# Altium schematic sheet Sheet2.SchDoc(Sheet2)
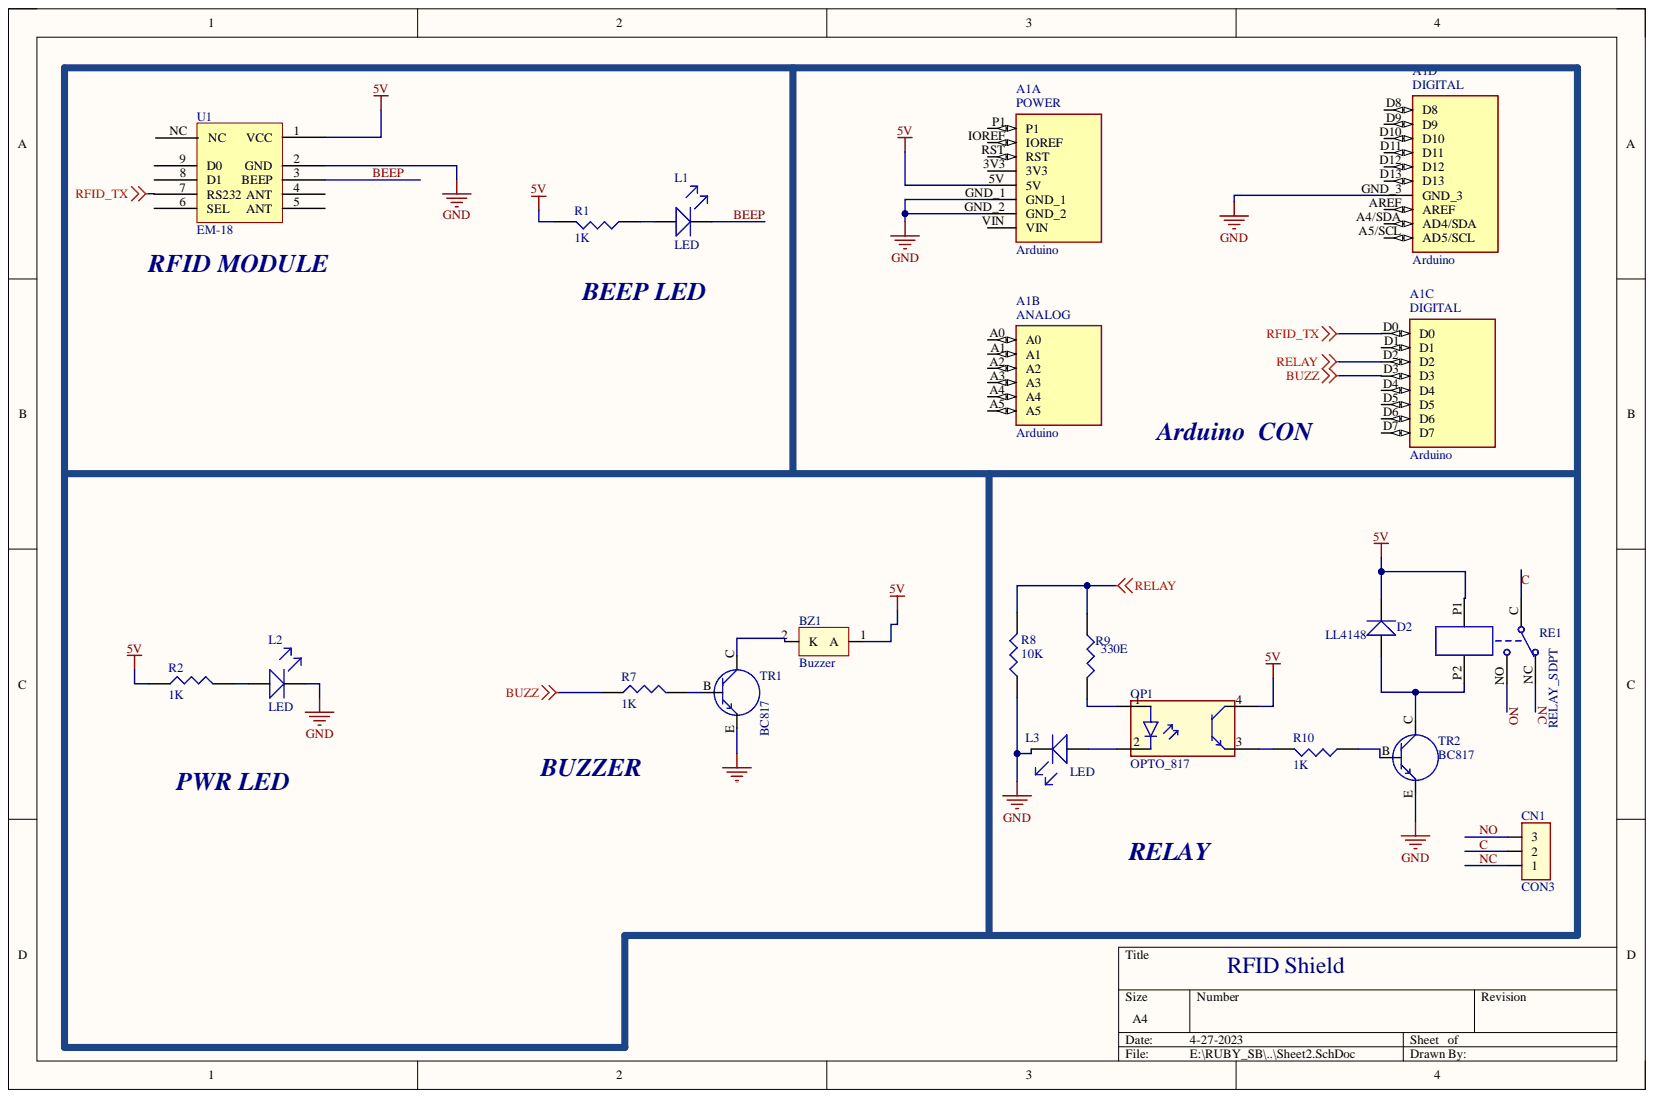
[diagram p0: Sheet2.SchDoc]

## Parts
A1  Arduino  [Arduino]  (parts A,B,C,D)
BZ1  Buzzer  [Buzzer]
CN1  CON3  [3P_5MM]
D2  LL4148  [LL4148_SOD80]
L1  LED  [LED_0603]
L2  LED  [LED_0603]
L3  LED  [LED_0603]
OP1  OPTO_817  [EL357N_OPTO]
R1  1K  [R_0603]
R2  1K  [R_0603]
R7  1K  [R_0603]
R8  10K  [R_0603]
R9  330E  [R_0603]
R10  1K  [R_0603]
RE1  RELAY_SDPT  [HLS8L-DC5V-S-C RELAY_5V]
TR1  BC817  [SOT23]
TR2  BC817  [SOT23]
U1  EM-18  [RFID]

## Nets
5V: A1.5V BZ1.1 D2.K OP1.4 R1.2 R2.1 RE1.P1 U1.1(VCC)
BUZZ: A1.D3 R7.1
GND: A1.GND_1 A1.GND_2 A1.GND_3 L2.K L3.K R8.1 TR1.E TR2.E U1.2(GND)
RELAY: A1.D2 R8.2 R9.1
RFID_TX: A1.D0 U1.7(RS232)
BEEP: L1.K U1.3(BEEP)
C: CN1.2 RE1.C
NC: CN1.1 RE1.NC
NO: CN1.3 RE1.NO
NetBZ1_2: BZ1.2 TR1.C
NetD2_A: D2.A RE1.P2 TR2.C
NetL1_A: L1.A R1.1
NetL2_A: L2.A R2.2
NetL3_A: L3.A OP1.2
NetOP1_1: OP1.1 R9.2
NetOP1_3: OP1.3 R10.2
NetR7_2: R7.2 TR1.B
NetR10_1: R10.1 TR2.B

Not connected: A1 3V3 A0 A1 A2 A3 A4 A4/SDA(AD4/SDA) A5 A5/SCL(AD5/SCL) AREF D1 D4 D5 D6 D7 D8 D9 D10 D11 D12 D13 IOREF P1 RST VIN; U1 4(ANT) 5(ANT) 6(SEL) 8(D1) 9(D0) NC

## Library data of the parts
A1 = Arduino: Microcontroller board, Uno [Arduino] A000066 Microcontroller board, Uno 7640152110092 <a href="https://pricing.snapeda.com/parts/A000066/Arduino/view-part?ref=eda">Check prices</a> <a href="https://pricing.snapeda.com/parts/A000066/Arduino/view-part?ref=eda">Check prices</a>; MP: ARDUINO UNO REV3; Availability: Unavailable; Component Kind: Standard; Package: None; Price: None; Library Name: MIX.SchLib
D2 = DIODE: Component Kind: Standard; Library Name: dxp_pasl_lib.IntLib
R1 R2 R7-R10 = RES: Component Kind: Standard; Library Name: dxp_pasl_lib.IntLib
TR1 TR2 = TRANSISTER_NPN: Component Kind: Standard; Library Name: dxp_pasl_lib.IntLib
U1 = EM-18 RFID: 8MHz XO (Standard) CMOS Oscillator 3.3V Standby (Power Down) 4-SMD, No Lead; Component Kind: Standard; Library Name: dxp_pasl_lib.IntLib
BZ1 = Buzzer: Component Kind: Standard; Library Name: dxp_pasl_lib.IntLib
CN1 = CON3: Component Kind: Standard; Library Name: dxp_pasl_lib.IntLib
L1-L3 = LED: Component Kind: Standard; Library Name: dxp_pasl_lib.IntLib
OP1 = OPTO_817: Component Kind: Standard; Library Name: dxp_pasl_lib.IntLib
RE1 = RELAY_SDPT: Component Kind: Standard; Library Name: dxp_pasl_lib.IntLib
